# Altium schematic sheet ESP32-CAM.SchDoc
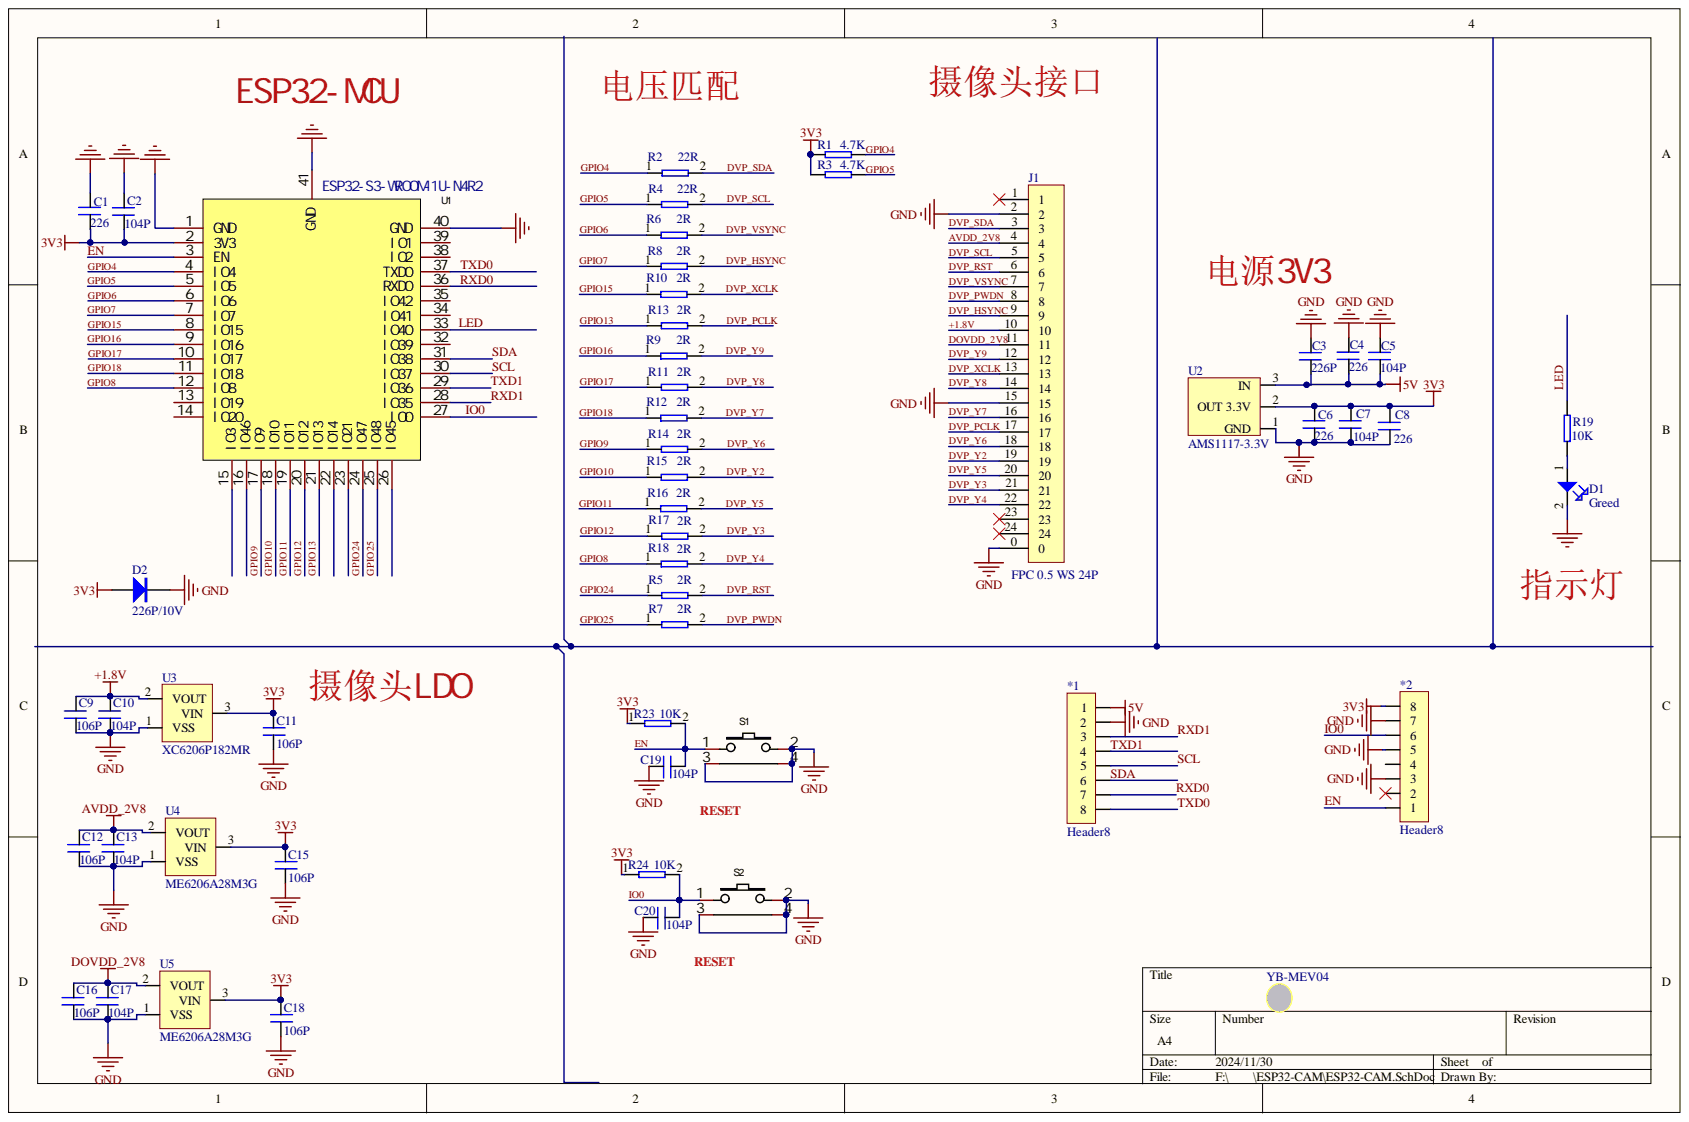
[diagram p0: ESP32-CAM.SchDoc]

## Parts
*1  Header8  [HDR8]
*2  Header8  [HDR8]
C1  226  [C0603]
C2  104P  [C0603]
C3  226P  [C0603]
C4  226  [C0603]
C5  104P  [C0402]
C6  226  [C0603]
C7  104P  [C0402]
C8  226  [C0603]
C9  106P  [C0402]
C10  104P  [C0402]
C11  106P  [C0402]
C12  106P  [C0402]
C13  104P  [C0402]
C15  106P  [C0402]
C16  106P  [C0402]
C17  104P  [C0402]
C18  106P  [C0402]
C19  104P  [C0402]
C20  104P  [C0402]
D1  Greed  [D0603-G]
D2  226P/10V  [CASE-A_3216]
J1  FPC 0.5 WS 24P  [FPC0.5 2H-WS-24P]
R1  4.7K  [R0402]
R2  22R  [R0402]
R3  4.7K  [R0402]
R4  22R  [R0402]
R5  2R  [R0402]
R6  2R  [R0402]
R7  2R  [R0402]
R8  2R  [R0402]
R9  2R  [R0402]
R10  2R  [R0402]
R11  2R  [R0402]
R12  2R  [R0402]
R13  2R  [R0402]
R14  2R  [R0402]
R15  2R  [R0402]
R16  2R  [R0402]
R17  2R  [R0402]
R18  2R  [R0402]
R19  10K  [R0603]
R23  10K  [R0402]
R24  10K  [R0402]
S1  (no value)  [SW-SMD_3.3x4.5mm]
S2  (no value)  [SW-SMD_3.3x4.5mm]
U1  ESP32-S3-WROOM-1U-N4R2  [ESP32-S3-WROOM-1U-N4R2]
U2  AMS1117-3.3V  [SOT-223]
U3  XC6206P182MR  [SOT-23-3L]
U4  ME6206A28M3G  [SOT-23-3L]
U5  ME6206A28M3G  [SOT-23-3L]
YB-MEV04  (no value)  [LGO18.77MM]

## Nets
3V3: *2.8 C1.2 C2.2 C6.1 C7.1 C8.1 C11.2 C15.2 C18.2 D2.1 R1.2 R3.2 R23.1 R24.1 U1.2(3V3) U2.2 U3.3 U4.3 U5.3
5V: *1.1 C3.2 C4.2 C5.2 U2.3
GND: *1.2 *2.3 *2.5 *2.7 C1.1 C2.1 C3.1 C4.1 C5.1 C6.2 C7.2 C8.2 C9.2 C10.2 C11.1 C12.2 C13.2 C15.1 C16.2 C17.2 C18.1 C19.1 C20.1 D1.2 D2.2 J1.0 J1.2 J1.15 S1.2 S1.3 S1.4 S2.2 S2.3 S2.4 U1.1(GND) U1.40(GND) U1.41(GND) U2.1 U3.1 U4.1 U5.1
+1.8V: C9.1 C10.1 J1.10 U3.2
AVDD_2V8: C12.1 C13.1 J1.4 U4.2
DOVDD_2V8: C16.1 C17.1 J1.11 U5.2
DVP_HSYNC: J1.9 R8.2
DVP_PCLK: J1.17 R13.2
DVP_PWDN: J1.8 R7.2
DVP_RST: J1.6 R5.2
DVP_SCL: J1.5 R4.2
DVP_SDA: J1.3 R2.2
DVP_VSYNC: J1.7 R6.2
DVP_XCLK: J1.13 R10.2
DVP_Y2: J1.19 R15.2
DVP_Y3: J1.21 R17.2
DVP_Y4: J1.22 R18.2
DVP_Y5: J1.20 R16.2
DVP_Y6: J1.18 R14.2
DVP_Y7: J1.16 R12.2
DVP_Y8: J1.14 R11.2
DVP_Y9: J1.12 R9.2
EN: *2.1 C19.2 R23.2 S1.1 U1.3(EN)
GPIO4: R1.1 R2.1 U1.4(IO4)
GPIO5: R3.1 R4.1 U1.5(IO5)
GPIO6: R6.1 U1.6(IO6)
GPIO7: R8.1 U1.7(IO7)
GPIO8: R18.1 U1.12(IO8)
GPIO9: R14.1 U1.17(IO9)
GPIO10: R15.1 U1.18(IO10)
GPIO11: R16.1 U1.19(IO11)
GPIO12: R17.1 U1.20(IO12)
GPIO13: R13.1 U1.21(IO13)
GPIO15: R10.1 U1.8(IO15)
GPIO16: R9.1 U1.9(IO16)
GPIO17: R11.1 U1.10(IO17)
GPIO18: R12.1 U1.11(IO18)
GPIO24: R5.1 U1.24(IO47)
GPIO25: R7.1 U1.25(IO48)
IO0: *2.6 C20.2 R24.2 S2.1 U1.27(IO0)
LED: R19.2 U1.33(IO40)
RXD0: *1.7 U1.36(RXD0)
RXD1: *1.3 U1.28(IO35)
SCL: *1.5 U1.30(IO37)
SDA: *1.6 U1.31(IO38)
TXD0: *1.8 U1.37(TXD0)
TXD1: *1.4 U1.29(IO36)
NetD1_1: D1.1 R19.1
N00042:

Not connected: *2 2 4; J1 1 23 24; U1 13(IO19) 14(IO20) 15(IO3) 16(IO46) 22(IO14) 23(IO21) 26(IO45) 32(IO39) 34(IO41) 35(IO42) 38(IO2) 39(IO1)

## Library data of the parts
C1-C13 C15-C20 = Cap: Component Kind: Standard; Library Name: CZ 3D.IntLib
D1 = LED-贴片: Component Kind: Standard; Library Name: CZ 3D.IntLib
D2 = 1N4007: 整流二极管; 封装库来自淘宝卖家 ID：黄晶宝石: 其他店铺购入均为盗版，不享受售后技术支持服务，请立即申请退款; 淘宝ID: 封装库来自淘宝 ID：黄晶宝石; BOM名称: 整流二极管; Component Kind: Standard; 联系售后，技术支持: https://shop463601444.taobao.com; Library Name: 常见元器件.SchLib
R1 R3 R19 R23 R24 = Res: Component Kind: Standard; Library Name: CZ 3D.IntLib
R2 R4-R18 = RN: Component Kind: Standard; Library Name: 51+arm.SchLib
S1 S2 = GT-TC100B: Manufacturer: G-Switch(品赞); Actuator/Cap Color: 黑色; Actuator Style: 圆形按钮; Add into BOM: yes; Circuit: 单刀单掷; Contact Current: 50mA; Device: GT-TC100B-H085-L1; Insulation Resistance: 100MΩ; Mechanical Life: 100000; Operating Force: 250gf@±30gf; Pin Style: PC引脚; Strike Gundam: 是; Switch Height: 8.5mm; Switch Length: 7.3mm; Switch Width: 7.1mm; Voltage Rating (DC): 12V; With Lamp: 无; Component Kind: Standard; Convert to PCB: yes; Datasheet: https://atta.szlcsc.com/upload/public/pdf/source/20210430/C2829957_A35EF342947E40262F54E7BA8493B4E3.pdf; JLCPCB Part Class: Extended Part; LCSC Part Name: 6×6支架轻触开关 CH4.0; Library Name: CZ 3D.SchLib; Manufacturer Part: GT-TC100B-H085-L1; Mounting Style: 卧插; Name: GT-TC100B-H085-L1; Operating Temperature: -30℃~+85℃; PCB3D: KEY-TH_4P-L7.5-W6.8-P4.50-LS7.2; Supplier: LCSC; Supplier Footprint: 插件; Supplier Part: C2829963; Symbol: GT-TC100B-H085-L1
U1 = ESP32-S3-WROOM-1U-N8R8: Manufacturer: ESPRESSIF(乐鑫); Add into BOM: yes; Component Kind: Standard; Convert to PCB: yes; Datasheet: https://atta.szlcsc.com/upload/public/pdf/source/20220628/A2AC12B3C87735CAEB7EBE2DBE80936C.pdf; Device: ESP32-S3-WROOM-1U-N8R8; JLCPCB Part Class: Extended Part; LCSC Part Name: ESP32-S3-WROOM-1U-N8R8; Library Name: CZ 3D.SchLib; Manufacturer Part: ESP32-S3-WROOM-1U-N8R8; Name: ESP32-S3-WROOM-1U-N8R8; Supplier: LCSC; Supplier Footprint: SMD; Supplier Part: C2980300; Symbol: ESP32-S3-WROOM-1U-N8R8
U2 = AMS1117: Component Kind: Standard; Library Name: CZ 3D.IntLib
U3-U5 = XC6206 3.3V: Component Kind: Standard; Library Name: CZ 3D.IntLib
YB-MEV04 = LOGO: Component Kind: Standard; Library Name: CZ 3D.IntLib
*1 *2 = Header8: Component Kind: Standard; Library Name: CZ 3D.SchLib
J1 = FPC 0.5 WS 24P: Component Kind: Standard; Library Name: CZ 3D.IntLib
